# Altium schematic sheet 功率板.SchDoc
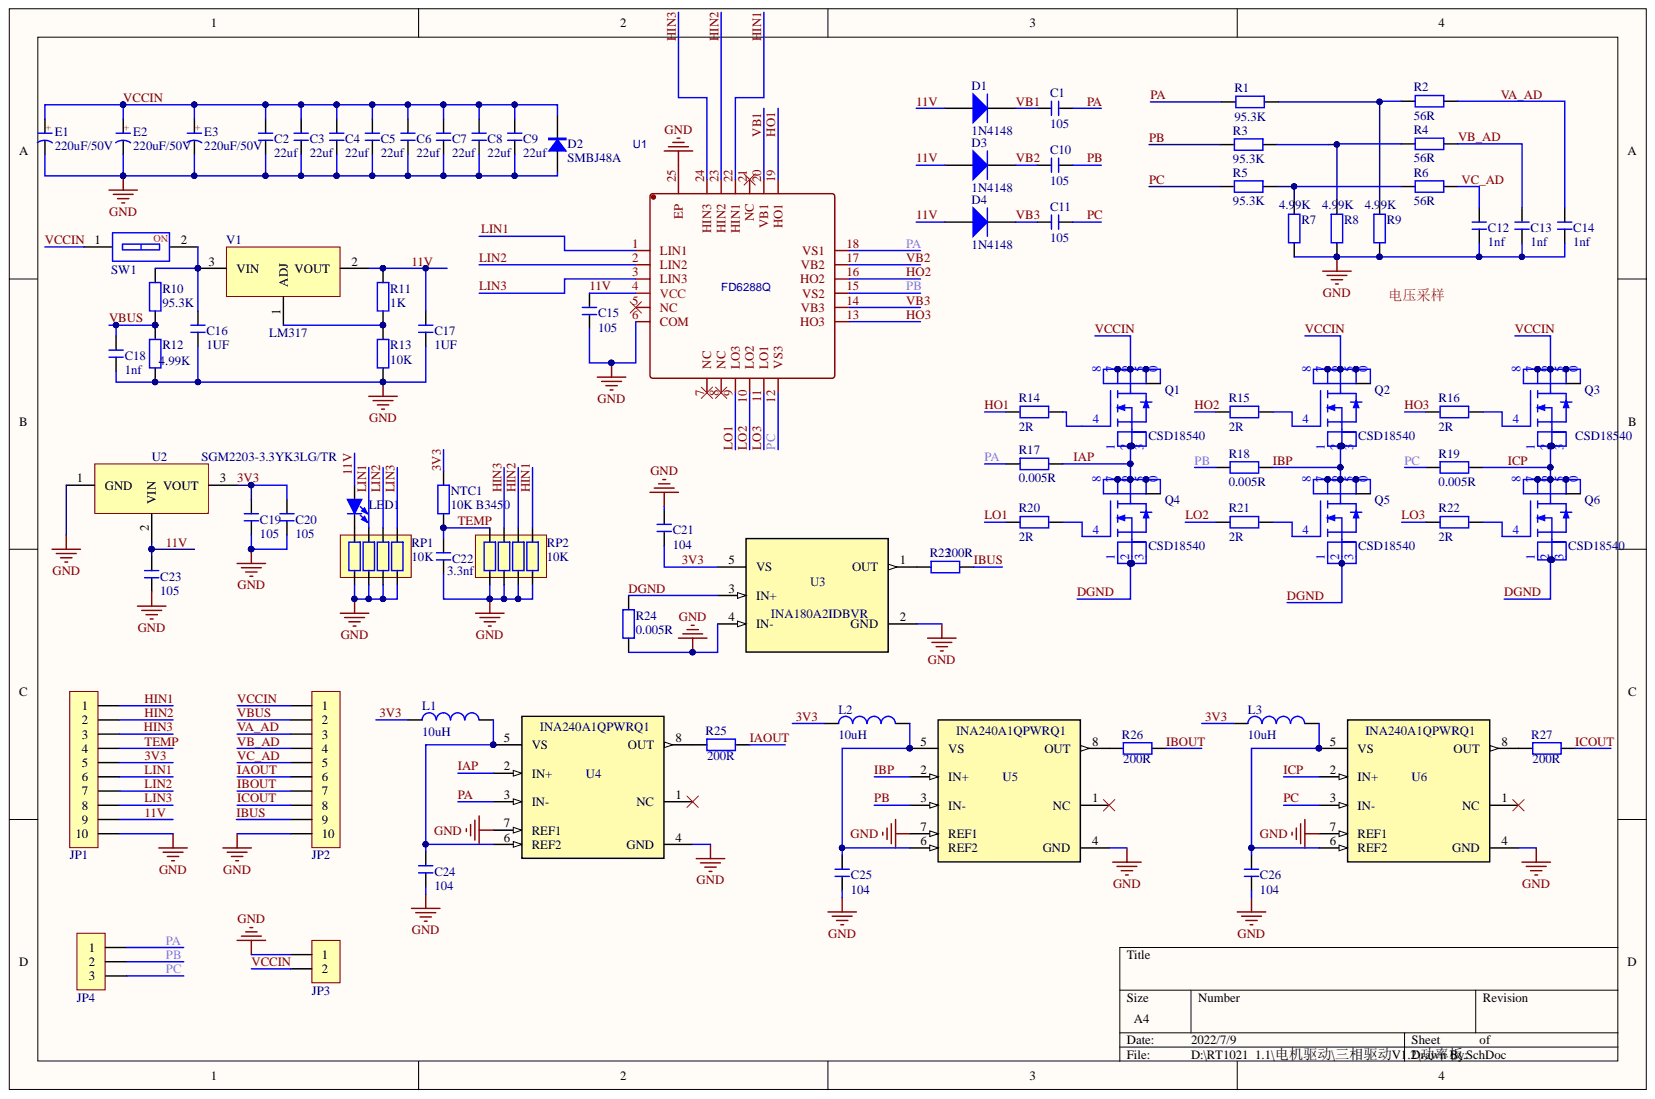
[diagram p0: 功率板.SchDoc]

## Parts
C1  105  [C 0603_L]
C2  22uf  [C 1210_L]
C3  22uf  [C 1210_L]
C4  22uf  [C 1210_L]
C5  22uf  [C 1210_L]
C6  22uf  [C 1210_L]
C7  22uf  [C 1210_L]
C8  22uf  [C 1210_L]
C9  22uf  [C 1210_L]
C10  105  [C 0603_L]
C11  105  [C 0603_L]
C12  1nf  [C 0603_L]
C13  1nf  [C 0603_L]
C14  1nf  [C 0603_L]
C15  105  [C 0603_L]
C16  1UF  [C 0603_L]
C17  1UF  [C 0603_L]
C18  1nf  [C 0603_L]
C19  105  [C 0603_L]
C20  105  [C 0603_L]
C21  104  [C 0603_L]
C22  3.3nf  [C 0603_L]
C23  105  [C 0603_L]
C24  104  [C 0603_L]
C25  104  [C 0603_L]
C26  104  [C 0603_L]
D1  1N4148  [SOD-523]
D2  SMBJ48A  [SMB]
D3  1N4148  [SOD-523]
D4  1N4148  [SOD-523]
E1  220uF/50V  [CS 5.0*10*13 - R]
E2  220uF/50V  [CS 5.0*10*13 - R]
E3  220uF/50V  [CS 5.0*10*13 - R]
JP1  HDR-1X10  [HDR2.0-M-LI-10P]  (value not drawn)
JP2  HDR-1X10  [HDR2.0-M-LI-10P]  (value not drawn)
JP3  HDR-1X2  [KF2EDGK5.08-WI-2P]  (value not drawn)
JP4  HDR-1X3  [KF2EDGK5.08-WI-3P]  (value not drawn)
L1  10uH  [L 0603_L]
L2  10uH  [L 0603_L]
L3  10uH  [L 0603_L]
LED1  红色  [LED 0603W]  (value not drawn)
NTC1  10K B3450  [R 0603_L]
Q1  CSD18540  [DFN5x6]
Q2  CSD18540  [DFN5x6]
Q3  CSD18540  [DFN5x6]
Q4  CSD18540  [DFN5x6]
Q5  CSD18540  [DFN5x6]
Q6  CSD18540  [DFN5x6]
R1  95.3K  [R 0603_L]
R2  56R  [R 0603_L]
R3  95.3K  [R 0603_L]
R4  56R  [R 0603_L]
R5  95.3K  [R 0603_L]
R6  56R  [R 0603_L]
R7  4.99K  [R 0603_L]
R8  4.99K  [R 0603_L]
R9  4.99K  [R 0603_L]
R10  95.3K  [R 0603_L]
R11  1K  [R 0603_L]
R12  4.99K  [R 0603_L]
R13  10K  [R 0603_L]
R14  2R  [R 0603_L]
R15  2R  [R 0603_L]
R16  2R  [R 0603_L]
R17  0.005R  [R 1206-HP_L]
R18  0.005R  [R 1206-HP_L]
R19  0.005R  [R 1206-HP_L]
R20  2R  [R 0603_L]
R21  2R  [R 0603_L]
R22  2R  [R 0603_L]
R23  200R  [R 0603_L]
R24  0.005R  [R 1206-HP_L]
R25  200R  [R 0603_L]
R26  200R  [R 0603_L]
R27  200R  [R 0603_L]
RP1  10K  [RCA-8P4R-0603]
RP2  10K  [RCA-8P4R-0603]
SW1  CSW-1P  [CSW DIP-1P]  (value not drawn)
U1  FD6288Q  [QFN24_4x4]
U2  SGM2203-3.3YK3LG/TR  [SOT89_M]
U3  INA180A2IDBVR  [SOT23-5]
U4  INA240A1QPWRQ1  [TSSOP8_L]
U5  INA240A1QPWRQ1  [TSSOP8_L]
U6  INA240A1QPWRQ1  [TSSOP8_L]
V1  LM317  [SOT223_L]

## Nets
GND: C2.1 C3.1 C4.1 C5.1 C6.1 C7.1 C8.1 C9.1 C12.2 C13.2 C14.2 C15.1 C16.1 C17.1 C18.2 C19.1 C20.1 C21.2 C22.1 C23.1 C24.1 C25.1 C26.1 D2.1 E1.2 E2.2 E3.2 JP1.10 JP2.10 JP3.1 R7.1 R8.1 R9.1 R12.1 R13.1 R24.1 RP1.1 RP1.2 RP1.3 RP1.4 RP2.1 RP2.2 RP2.3 RP2.4 U1.6(COM) U1.25(EP) U2.1 U3.2(GND) U3.4(IN-) U4.4(GND) U4.7(REF1) U5.4(GND) U5.7(REF1) U6.4(GND) U6.7(REF1)
3V3: C19.2 C20.2 C21.1 JP1.5 L1.1 L2.1 L3.1 NTC1.2 U2.3 U3.5(VS)
11V: C15.2 C17.2 C23.2 D1.1 D3.1 D4.1 JP1.9 LED1.1 R11.2 U1.4(VCC) U2.2 V1.2
DGND: Q4.1 Q4.2 Q4.3 Q5.1 Q5.2 Q5.3 Q6.1 Q6.2 Q6.3 R24.2 U3.3(IN+)
HIN1: JP1.1 RP2.5 U1.22(HIN1)
HIN2: JP1.2 RP2.6 U1.23(HIN2)
HIN3: JP1.3 RP2.7 U1.24(HIN3)
HO1: R14.1 U1.19(HO1)
HO2: R15.1 U1.16(HO2)
HO3: R16.1 U1.13(HO3)
IAOUT: JP2.6 R25.1
IAP: Q1.1 Q1.2 Q1.3 Q4.0 Q4.5 Q4.6 Q4.7 Q4.8 R17.1 U4.2(IN+)
IBOUT: JP2.7 R26.1
IBP: Q2.1 Q2.2 Q2.3 Q5.0 Q5.5 Q5.6 Q5.7 Q5.8 R18.1 U5.2(IN+)
IBUS: JP2.9 R23.1
ICOUT: JP2.8 R27.1
ICP: Q3.1 Q3.2 Q3.3 Q6.0 Q6.5 Q6.6 Q6.7 Q6.8 R19.1 U6.2(IN+)
LIN1: JP1.6 RP1.7 U1.1(LIN1)
LIN2: JP1.7 RP1.6 U1.2(LIN2)
LIN3: JP1.8 RP1.5 U1.3(LIN3)
LO1: R20.1 U1.9(LO3)
LO2: R21.1 U1.10(LO2)
LO3: R22.1 U1.11(LO1)
PA: C1.1 JP4.1 R1.1 R17.2 U1.18(VS1) U4.3(IN-)
PB: C10.1 JP4.2 R3.1 R18.2 U1.15(VS2) U5.3(IN-)
PC: C11.1 JP4.3 R5.1 R19.2 U1.12(VS3) U6.3(IN-)
TEMP: C22.2 JP1.4 NTC1.1 RP2.8
VA_AD: C14.1 JP2.3 R2.2
VB1: C1.2 D1.2 U1.20(VB1)
VB2: C10.2 D3.2 U1.17(VB2)
VB3: C11.2 D4.2 U1.14(VB3)
VB_AD: C13.1 JP2.4 R4.2
VBUS: C18.1 JP2.2 R10.1 R12.2
VC_AD: C12.1 JP2.5 R6.2
VCCIN: C2.2 C3.2 C4.2 C5.2 C6.2 C7.2 C8.2 C9.2 D2.2 E1.1 E2.1 E3.1 JP2.1 JP3.2 Q1.0 Q1.5 Q1.6 Q1.7 Q1.8 Q2.0 Q2.5 Q2.6 Q2.7 Q2.8 Q3.0 Q3.5 Q3.6 Q3.7 Q3.8 SW1.1
NetC16_2: C16.2 R10.2 SW1.2 V1.3
NetC24_2: C24.2 L1.2 U4.5(VS) U4.6(REF2)
NetC25_2: C25.2 L2.2 U5.5(VS) U5.6(REF2)
NetC26_2: C26.2 L3.2 U6.5(VS) U6.6(REF2)
NetLED1_2: LED1.2 RP1.8
NetQ1_4: Q1.4 R14.2
NetQ2_4: Q2.4 R15.2
NetQ3_4: Q3.4 R16.2
NetQ4_4: Q4.4 R20.2
NetQ5_4: Q5.4 R21.2
NetQ6_4: Q6.4 R22.2
NetR1_2: R1.2 R2.1 R9.2
NetR3_2: R3.2 R4.1 R8.2
NetR5_2: R5.2 R6.1 R7.2
NetR11_1: R11.1 R13.2 V1.1
NetR23_2: R23.2 U3.1(OUT)
NetR25_2: R25.2 U4.8(OUT)
NetR26_2: R26.2 U5.8(OUT)
NetR27_2: R27.2 U6.8(OUT)

Not connected: U1 5(NC) 7(NC) 8(NC) 21(NC); U4 1(NC); U5 1(NC); U6 1(NC)

## Library data of the parts
C1 C10 C11 = C: 无极性贴片电容; VALUE: 1uf 50v 10% X7R; BOM名称: 无极性贴片电容; Component Kind: Standard; PACKAGE: 0603; Copyright: habai
C2-C9 = C: 无极性贴片电容; VALUE: 22uf 50v 10% X7R; BOM名称: 无极性贴片电容; Component Kind: Standard; PACKAGE: 1210; Copyright: habai
C12-C14 C18 = C: 无极性贴片电容; VALUE: 1nf 50v 10% X7R; BOM名称: 无极性贴片电容; Component Kind: Standard; PACKAGE: 0603; Copyright: habai
C15 C21 = C: 无极性贴片电容; VALUE: 100nf 50v 10% X7R; BOM名称: 无极性贴片电容; Component Kind: Standard; PACKAGE: 0402; Copyright: habai
C16 C17 = C: 无极性贴片电容; VALUE: 1UF 25v 10% X7R; BOM名称: 无极性贴片电容; Component Kind: Standard; PACKAGE: 0603; Copyright: habai; Library Name: 基本.SchLib
C19 C20 C23 = C: 无极性贴片电容; value: 1uf 50v 10%; Component Kind: Standard; Library Name: 3.1   - 电阻-电容-电感.SchLib; package: 0603 x5r
C22 = C: 无极性贴片电容; value: 3.3nf 50v 10%; Component Kind: Standard; Library Name: 3.1   - 电阻-电容-电感.SchLib; package: 0603 x5r
C24-C26 = C: 无极性贴片电容; value: 100nf 50v 10%; Component Kind: Standard; Library Name: 3.1   - 电阻-电容-电感.SchLib; package: 0603 x5r
D1 D3 D4 = SMC(DO-214AB) SS36-E3/57T: 开关二极管; Component Kind: Standard; ComponentLink1Description: 供应商链接; ComponentLink1URL: http://www.szlcsc.com/product/details_36698.html; Package: SMC(DO-214AB); Supplier: LC; SuppliersPartNumber: C35722
D2 = D: 表贴肖特基二极管; BOM名称: TVS; 属性: 48V; 版权归属: 源创客; Component Kind: Standard; 学习资源: www.ooocke.com; Library Name: 基本.SchLib
E1-E3 = C-CD: 直插电解电容; BOM名称: 贴片电解电容; value: 10*13 50V/220UF; 版权归属: 源创客; Component Kind: Standard; 学习资源: www.ooocke.com; Library Name: 基本.SchLib
JP1 JP2 = Header 10: 10P接插件; 版权归属: 源创客; BOM名称: 10P接插件; Component Kind: Standard; Library Name: 接插件.SchLib; 学习资源: www.ooocke.com
JP3 = Header 2: 2P接插件; 版权归属: 源创客; BOM名称: 2P接插件; Component Kind: Standard; Library Name: 接插件.SchLib; 学习资源: www.ooocke.com
JP4 = Header 3: 3P接插件; 版权归属: 源创客; BOM名称: 3P接插件; Component Kind: Standard; Library Name: 接插件.SchLib; 学习资源: www.ooocke.com
L1-L3 = L: 小功率贴片电感; 版权归属: 源创客; BOM名称: 小功率贴片电感; Component Kind: Standard; 学习资源: www.ooocke.com; Library Name: 基本.SchLib
LED1 = LED-SMD: 贴片LED; VALUE: BLUE; BOM名称: 贴片LED; Component Kind: Standard; PACKAGE: 0603; Copyright: habai; Library Name: 基本.SchLib
NTC1 R11 R13 = R: 贴片电阻; VALUE: 1K 1%; BOM名称: 贴片电阻; Component Kind: Standard; PACKAGE: 0603; Copyright: habai; Library Name: 基本.SchLib
Q1-Q6 = N-MOS_1: NCEP070N10AGU/CSD19532Q5B; Component Kind: Standard; Library Name: XTQ Schlib.SchLib
R1-R10 R12 = R: 贴片电阻; VALUE: 95.3K 1%; Component Kind: Standard; Library Name: 3.1   - 电阻-电容-电感.SchLib; PACKAGE: 0603
R14-R16 R20-R22 = R: 贴片电阻; VALUE: 2R 1%; Component Kind: Standard; Library Name: 3.1   - 电阻-电容-电感.SchLib; PACKAGE: 0603
R17-R19 R24 = R-I: 电流检测电阻; 版权归属: 源创客; BOM名称: 电流检测电阻; Component Kind: Standard; 学习资源: www.ooocke.com; Library Name: 基本.SchLib
R23 R25-R27 = R: 贴片电阻; VALUE: 200r 1%; BOM名称: 贴片电阻; Component Kind: Standard; PACKAGE: 0603; Copyright: habai; Library Name: 基本.SchLib
RP1 RP2 = R-8P4R: 贴片网络排阻; VALUE: 8P4R  10K 1%; Component Kind: Standard; PACKAGE: 8P4R 0603; Copyright: habai; Library Name: 基本.SchLib
SW1 = CSW-1P: 1路编码开关; 版权归属: 源创客; BOM名称: 1路编码开关; Component Kind: Standard; 学习资源: www.ooocke.com; Library Name: 基本.SchLib
U1 = FD6288Q_C328453: 三相栅极驱动; BOM_Manufacturer: Fortior Tech; Contributor: LCSC; BOM_JLCPCB Part Class: Extended Part; BOM_Manufacturer Part: FD6288Q; BOM_Supplier: LCSC; BOM_Supplier Part: C328453; Component Kind: Standard; spicePre: U; spiceSymbolName: FD6288Q_C328453
U2 = LM317: 线性稳压芯片; value: LDO 40V; Component Kind: Standard; Library Name: 1.3   - 电源类芯片.SchLib; package: SOT-89 LTYP
U3 = INA180A1IDBVR: No Description Available; Mfr_Name: Texas Instruments; Component Kind: Standard; Manufacturer_Part_Number: INA180A1IDBVR; RefDes: RefDes; Type: DEV; Copyright: Copyright (C) 2022 Ultra Librarian. All rights reserved.
U4-U6 = INA240A1QPWRQ1: No Description Available; Mfr_Name: Texas Instruments; Component Kind: Standard; Manufacturer_Part_Number: INA240A1QPWRQ1; RefDes: RefDes; Type: DEV; Copyright: Copyright (C) 2022 Ultra Librarian. All rights reserved.
V1 = LM317: 可调线性稳压芯片; 版权归属: 源创客; BOM名称: 可调线性稳压芯片; Component Kind: Standard; Library Name: 1.3   - 电源类芯片.SchLib; 学习资源: www.ooocke.com
